# Altium schematic sheet microcontroleur.SchDoc(microcontroleur)
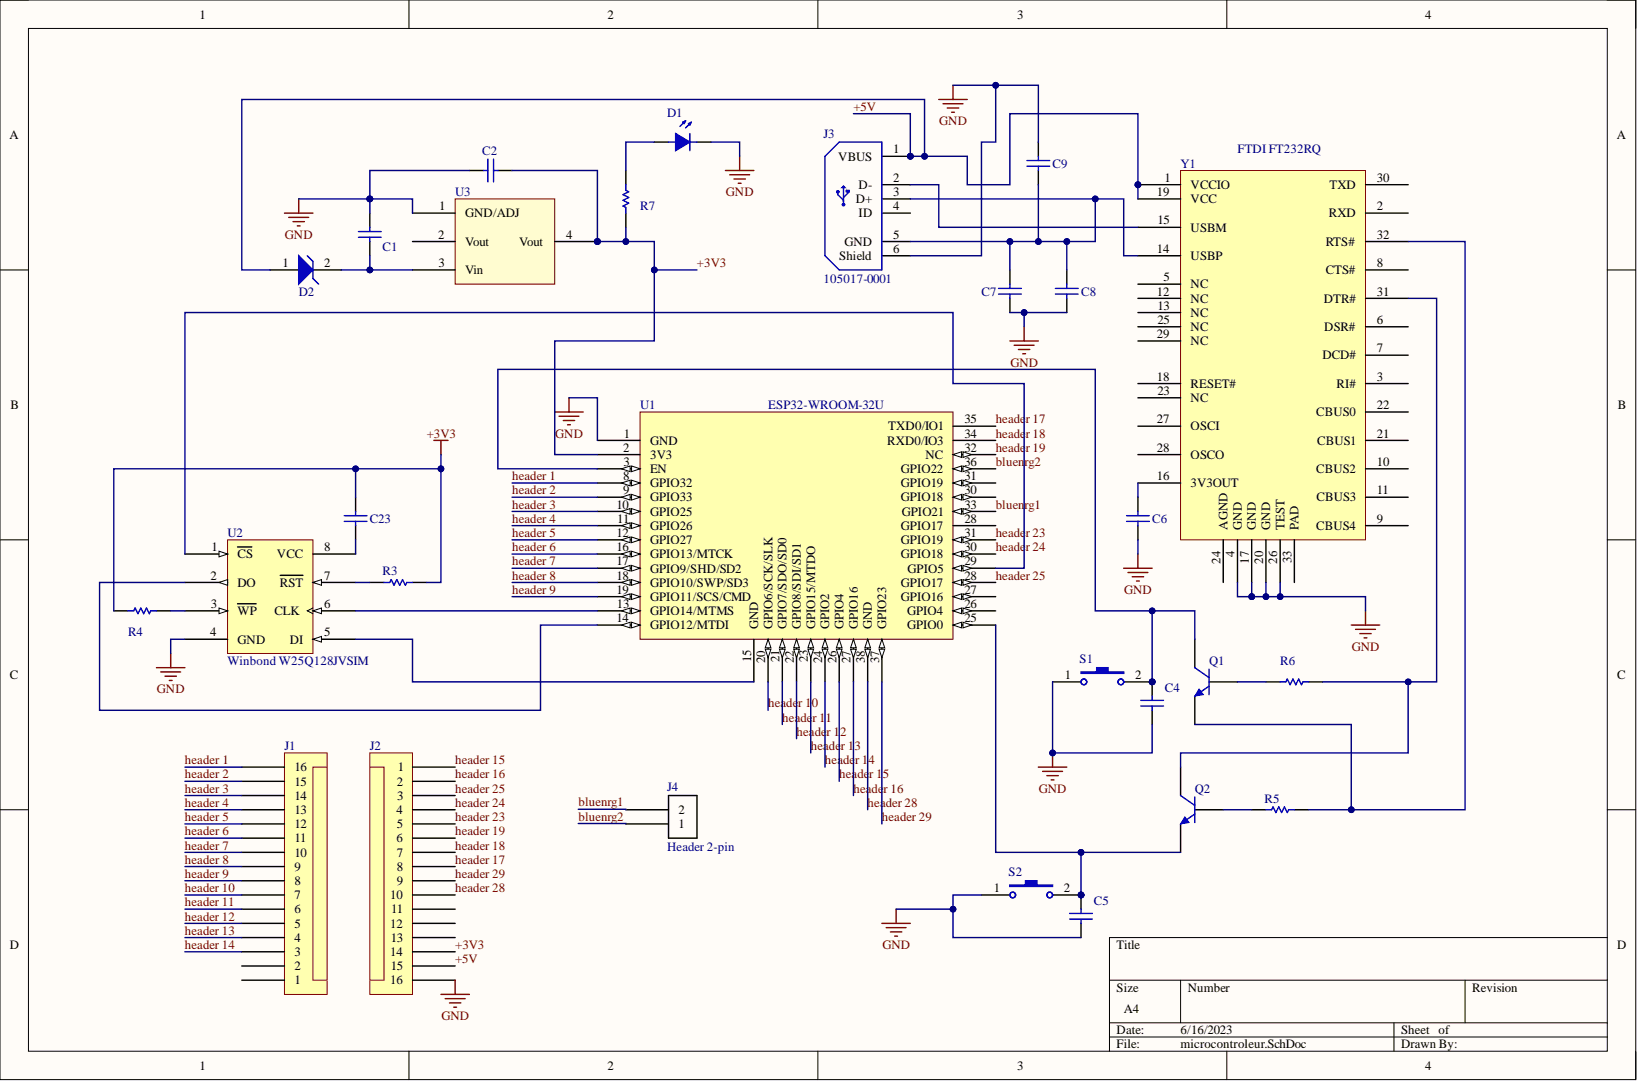
[diagram p0: microcontroleur.SchDoc]

## Parts
C1  Walsin Technologies 1206B223K500CT  [PCB-8xkryqnx9b6lv7lehhrv-1]  (value not drawn)
C2  Walsin Technologies 1206B223K500CT  [PCB-8xkryqnx9b6lv7lehhrv-1]  (value not drawn)
C4  Walsin Technologies 1206B223K500CT  [PCB-8xkryqnx9b6lv7lehhrv-1]  (value not drawn)
C5  Walsin Technologies 1206B223K500CT  [PCB-8xkryqnx9b6lv7lehhrv-1]  (value not drawn)
C6  Walsin Technologies 1206B223K500CT  [PCB-8xkryqnx9b6lv7lehhrv-1]  (value not drawn)
C7  Walsin Technologies 1206B223K500CT  [PCB-8xkryqnx9b6lv7lehhrv-1]  (value not drawn)
C8  Walsin Technologies 1206B223K500CT  [PCB-8xkryqnx9b6lv7lehhrv-1]  (value not drawn)
C9  Walsin Technologies 1206B223K500CT  [PCB-8xkryqnx9b6lv7lehhrv-1]  (value not drawn)
C23  Walsin Technologies 1206B223K500CT  [PCB-8xkryqnx9b6lv7lehhrv-1]  (value not drawn)
D1  Lumex SSF-LXH103GD  [PCB-xr7eaxl9d3mmzm5lh73h-1]  (value not drawn)
D2  Diodes Inc. DDZ5V6B-7  (value not drawn)
J1  TE Connectivity 1-640456-6  [PCB-345877-1]  (value not drawn)
J2  TE Connectivity 1-640456-6  [PCB-345877-1]  (value not drawn)
J3  105017-0001  [PCB-mhad68of2isnyuvs24zh-1]
J4  Header 2-pin  [PCB-psmpzu51py3p54h2h388-1]
Q1  NPN Transistor 2  (value not drawn)
Q2  NPN Transistor 2  (value not drawn)
R3  Vishay Dale TNPU1206100KBZEN00  (value not drawn)
R4  Vishay Dale TNPU1206100KBZEN00  (value not drawn)
R5  Vishay Dale TNPU1206100KBZEN00  (value not drawn)
R6  Vishay Dale TNPU1206100KBZEN00  (value not drawn)
R7  Vishay Dale TNPU1206100KBZEN00  (value not drawn)
S1  E-Switch TL1015AF160QG  [PCB-p4sr6ekfdmcv8ck9ubvv-1]  (value not drawn)
S2  E-Switch TL1015AF160QG  [PCB-p4sr6ekfdmcv8ck9ubvv-1]  (value not drawn)
U1  ESP32-WROOM-32U
U2  Winbond W25Q128JVSIM  Manufacturer Part Number: W25Q128JVSIM  [PCB-wh1oml3ncb9rp3yf09m2-1]
U3  Advanced Monolithic Systems AMS1117-3.3  (value not drawn)
Y1  FTDI FT232RQ  Manufacturer Part Number: FT232RQ  [PCB-t5jruenkqa58z3zbpkiv-1]

## Nets
GND: C1.1 C2.1 C4.1 C5.1 C6.1 C7.1 C8.1 C9.2 D1.2 J2.16 J3.6(Shield) S1.1 S2.1 U1.1(GND) U2.4(GND) U3.1(GND/ADJ) Y1.4(GND) Y1.17(GND) Y1.20(GND) Y1.26(TEST)
+3V3: C2.2 C23.2 J2.14 R3.2 R4.1 R7.2 U1.2(3V3) U3.4(Vout)
+5V: D2.1 J2.15 J3.1(VBUS) Y1.1(VCCIO) Y1.19(VCC)
bluenrg1: J4.2 U1.33(GPIO21)
bluenrg2: J4.1 U1.36(GPIO22)
header 1: J1.16 U1.8(GPIO32)
header 2: J1.15 U1.9(GPIO33)
header 3: J1.14 U1.10(GPIO25)
header 4: J1.13 U1.11(GPIO26)
header 5: J1.12 U1.12(GPIO27)
header 6: J1.11 U1.16(GPIO13/MTCK)
header 7: J1.10 U1.17(GPIO9/SHD/SD2)
header 8: J1.9 U1.18(GPIO10/SWP/SD3)
header 9: J1.8 U1.19(GPIO11/SCS/CMD)
header 10: J1.7 U1.20(GPIO6/SCK/SLK)
header 11: J1.6 U1.21(GPIO7/SDO/SD0)
header 12: J1.5 U1.22(GPIO8/SDI/SD1)
header 13: J1.4 U1.23(GPIO15/MTDO)
header 14: J1.3 U1.24(GPIO2)
header 15: J2.1 U1.26
header 16: J2.2 U1.27
header 17: J2.8 U1.35(TXD0/IO1)
header 18: J2.7 U1.34(RXD0/IO3)
header 19: J2.6 U1.32(NC)
header 23: J2.5 U1.31
header 24: J2.4 U1.30
header 25: J2.3 U1.28
header 28: J2.10 U1.38(GND)
header 29: J2.9 U1.37(GPIO23)
NetC1_2: C1.2 D2.2 U3.3(Vin)
NetC4_2: C4.2 Q1.3 S1.2 U1.3(EN)
NetC5_2: C5.2 Q2.1 S2.2 U1.25(GPIO0)
NetC6_2: C6.2 Y1.16(3V3OUT)
NetC7_2: C7.2 C8.2 C9.1 J3.3(D+) J3.5(GND) Y1.14(USBP)
NetC23_1: C23.1 U2.8(VCC)
NetD1_1: D1.1 R7.1
NetJ3_2: J3.2(D-) Y1.15(USBM)
NetQ1_1: Q1.1 R5.2 Y1.32(RTS#)
NetQ1_2: Q1.2 R6.1
NetQ2_2: Q2.2 R5.1
NetQ2_3: Q2.3 R6.2 Y1.31(DTR#)
NetR3_1: R3.1 U2.7(RST)
NetR4_2: R4.2 U2.3(WP)
NetU1_13: U1.13(GPIO14/MTMS) U2.6(CLK)
NetU1_14: U1.14(GPIO12/MTDI) U2.2(DO)
NetU1_15: U1.15(GND) U2.5(DI)
NetU1_29: U1.29(GPIO5) U2.1(CS)

Not connected: J1 1 2; J2 11 12 13; J3 4(ID); U3 2(Vout); Y1 2(RXD) 3(RI#) 5(NC) 6(DSR#) 7(DCD#) 8(CTS#) 9(CBUS4) 10(CBUS2) 11(CBUS3) 12(NC) 13(NC) 18(RESET#) 21(CBUS1) 22(CBUS0) 23(NC) 24(AGND) 25(NC) 27(OSCI) 28(OSCO) 29(NC) 30(TXD) 33(PAD)

## Library data of the parts
C1 C2 C4-C9 C23 = CMP-ff1d3758a85f20da-2: Multilayer Ceramic Capacitor, 0.022 F, 10%, X7R, 50 V, 1206 [3216 Metric] Rohs Compliant: Yes; Capacitance: 22.0 nF; Voltage Rating (DC): 50.0 V; Capacitance Tolerance: ±10%; Case/Package: 1206; Component Kind: Standard; Dielectric Characteristic: X7R; Library Name: My Personal Space; Manufacturer Part Number: 1206B223K500CT; Mounting Style: Surface Mount; Octopart URL: https://octopart.com/1206b223k500ct-walsin+technologies-21983780; OctopartID: ff1d3758a85f20da; Operating Temperature: -55.0 °C (min); Packaging: Cut Tape (CT), Tape & Reel (TR); RoHS: Compliant
D1 = CMP-82784b7295a75dbf-2: Green 3 mm 60° Diffused 30 mcd 2.1 V Single Level LED Fault Indicator - T-1; Forward Voltage: 2.10 V; Lead-Free Status: Lead Free; Luminous Intensity: 30.0 mcd; Wavelength: 565 nm; Color: Green; Component Kind: Standard; Lens Color: Green; Lens Type: Diffused; Library Name: My Personal Space; Manufacturer Part Number: SSF-LXH103GD; Mounting Style: Through Hole, PCB; Octopart URL: https://octopart.com/ssf-lxh103gd-lumex-12985; OctopartID: 82784b7295a75dbf; Operating Temperature: 85.0 °C (max); Packaging: Bulk; RoHS: Compliant; Size-Diameter: 3.00 mm; Size-Height: 7.40 mm; Size-Width: 4.60 mm; Viewing Angle: 60 °
D2 = CMP-7605bf0a5065a9c2-3: Diode Zener Single 5.59V 3% 500mW Automotive 2-Pin SOD-123 T/R; Lead-Free Status: Lead Free; Output Current: 40.0 mA (max); Voltage Rating (DC): 5.60 V; Case/Package: SOD-123; Component Kind: Standard; Library Name: My Personal Space; Lifecycle Status: Active; Manufacturer Part Number: DDZ5V6B-7; Mounting Style: Surface Mount; Number of Pins: 2; Octopart URL: http://octopart.com/ddz5v6b-7-diodes+inc.-328454; OctopartID: 7605bf0a5065a9c2; Packaging: Cut Tape (CT), Tape & Reel (TR); Power Dissipation: 500 mW; Power Rating: 500 mW; REACH SVHC Compliance: No SVHC; RoHS: Compliant
J1 J2 = CMP-5cb5977adcc98372-4: Header, Friction Lock; MTA-100; 16; 250 VAC; Polyester; Tin; Copper Alloy; Tin; ELV Compliant: Compliant; Gender: Male; Lead-Free Status: Lead Free; Mounting Angle: 180 °; Number of Contacts: 16; Pin Pitch: 2.54 mm; Shrouded: No; Voltage Rating (AC): 250 V; Color: White; Component Kind: Standard; Contact Material: Copper Alloy; Contact Plating: Tin; Flammability Rating: UL 94 V-0; Housing Color: White; Housing Material: Polyester; Library Name: My Personal Space; Lifecycle Status: Active; Manufacturer Part Number: 1-640456-6; Mounting Style: Through Hole, Vertical; Number of Positions: 16; Number of Rows: 1; Octopart URL: https://octopart.com/1-640456-6-te+connectivity-46507290; OctopartID: 5cb5977adcc98372; Operating Temperature: 105 °C (max); Orientation: Vertical; Packaging: Bag; REACH SVHC Compliance: No SVHC; RoHS: Compliant; Size-Height: 7.87 mm; Size-Length: 40.6 mm; Size-Width: 5.71 mm; Voltage Rating (DC): 250 V
J3 = CMP-943259-34: MicroUSB connector, B-Type, SMT; Manufacturer Name: Molex; Gender: Female; Category: Connector; Component Kind: Standard; Datasheet PDF: https://www.molex.com/pdm_docs/sd/1050170001_sd.pdf; Halogen Free Status: Low Halogen; Library Name: My Personal Space; Manufacturer Part Number: 105017-0001; Mounting Style: Surface Mount; Octopart URL: http://octopart.com/105017-0001-molex-18688631; OctopartID: 846e4c798b7f5ba9; Orientation: Right Angle; Packaging: Cut Tape (CT); RoHS: Compliant; Supplier Name: Digikey; Supplier PN: WM1399CT-ND; Supplier URL: https://www.digikey.ca/product-detail/en/molex-llc/1050170001/WM1399CT-ND/2350885
J4 = CMP-2811e342b2f0f7d6-11: AMPMODU Mod II Series, 2.54mm Pitch 2Way1 Row Straight PCB Header, Solder Term, 3A; Manufacturer Part Number: 87224-2; ELV Compliant: Compliant; Gender: Male; Lead-Free Status: Lead Free; Mounting Angle: 180 °; Number of Contacts: 2; Color: Black; Component Kind: Standard; Contact Material: Phosphor Bronze; Contact Plating: Gold, Gold Flash over Nickel; Flammability Rating: UL 94 V-0; Housing Color: Black; Housing Material: Thermoplastic; Library Name: My Personal Space; Lifecycle Status: Active; Mounting Style: PCB; Number of Positions: 2; Number of Rows: 1; Octopart URL: http://octopart.com/87224-2-te+connectivity+%2F+amp-39436167; OctopartID: 2811e342b2f0f7d6; Orientation: Vertical; Packaging: Bulk; Pin Pitch: 2.54 mm; RoHS: Compliant; Size-Length: 4.67 mm
Q1 Q2 = CMP-e4ea2de0c6853b2d-4: NPN Transistor; Manufacturer Part Number: NTE159; Breakdown Voltage [Collector to Emitter]: V; Collector-Base Voltage: 80V; Emitter-Base Voltage: 5V; Polarity: PNP, P-Channel; Power Dissipation: 0.625 W; Case/Package: TO-92; Collector-Emitter Voltage: 80V; Component Kind: Standard; Library Name: My Personal Space; Number of Pins: 3; Octopart URL: http://octopart.com/nte159-nte+electronics-1801; OctopartID: e4ea2de0c6853b2d; REACH SVHC Compliance: No SVHC; RoHS: Compliant
R3-R7 = CMP-1863715-2: RES SMD 100K OHM 0.1% 1/4W 1206; Power Rating: 0.25 W; Resistance: 100000.0 Ω; Voltage Rating (DC): 200.0 V; Component Kind: Standard; Library Name: My Personal Space; Manufacturer Part Number: TNPU1206100KBZEN00; Octopart Url: https://octopart.com/tnpu1206100kbzen00-vishay+dale-44408838; OctopartID: c00b0ba4456b1fcc; Resistance Tolerance: ±0.1%; RoHS: Exempt
S1 S2 = CMP-dac213d8dc570505-5: Switch Tactile N.O. SPST Button J-Bend 0.05A 12VDC 200000Cycles 1.57N SMD T/R; Contact Current Rating: 50.0 mA; Contacts Type: SPST-NO; Lead-Free Status: Lead Free; Actuator Type: Button; Component Kind: Standard; Contact Plating: Silver; Library Name: My Personal Space; Manufacturer Part Number: TL1015AF160QG; Mounting Style: Surface Mount; Octopart URL: https://octopart.com/tl1015af160qg-e-switch-1057779; OctopartID: dac213d8dc570505; Operating Temperature: 70.0 °C (max); Orientation: Vertical; Packaging: Reel; REACH SVHC Compliance: No SVHC; RoHS: Compliant; Size-Height: 1.50 mm; Size-Length: 4.00 mm; Size-Width: 3.00 mm
U1 = CMP-ba1676706f1765d1-32: WiFi Modules (802.11) SMD Module, ESP32-D0WDQ6, 32Mbits SPI flash, UART Mode; Component Kind: Standard; Library Name: My Personal Space
U2 = CMP-f6a03b754ae22967-1: NOR Flash Serial (SPI, Dual SPI, Quad SPI) 3V/3.3V 128M-bit 16M x 8 6ns 8-Pin SOIC; Component Kind: Standard; Library Name: My Personal Space; Octopart URL: https://octopart.com/w25q128jvsim-winbond-81762596; OctopartID: f6a03b754ae22967
U3 = CMP-3dc0f5412982e207-2: 72dB@(120Hz) 1A 1.3V@(800mA) Fixed 3.3V~3.3V Positive 1 SOT-223  Linear Voltage Regulators (LDO) ROHS; Input Voltage: 5V; Output Voltage: 3.3V; Component Kind: Standard; JLCPCB Basic Part: Yes; JLCPCB Part #: C6186; JLCPCB Url: https://datasheet.lcsc.com/lcsc/1811142212_Advanced-Monolithic-Systems-AMS1117-3-3_C6186.pdf; LCSC Part Number: C6186; Library Name: My Personal Space; Manufacturer Part Number: AMS1117-3.3; Octopart Url: https://octopart.com/ams1117-3.3-advanced+monolithic+systems-25899300; OctopartID: 3dc0f5412982e207; Output Current: 1A; Package: SOT-233
Y1 = CMP-f96f304508b726a8-1: USB-to-UART 1-CH 256Byte FIFO 5V 32-Pin QFN EP; Bandwidth: 48.0 MHz; Data Rate: 3.00 Mbps; Lead-Free Status: Lead Free; Supply Current: 15.0 mA; Supply Voltage (DC): 3.30 V (min); Case/Package: QFN; Component Kind: Standard; Library Name: My Personal Space; Mounting Style: Surface Mount; Number of Pins: 32; Octopart URL: http://octopart.com/ft232rq-ftdi-2151614; OctopartID: f96f304508b726a8; Packaging: Cut Tape (CT); REACH SVHC Compliance: No SVHC; RoHS: Compliant
